# Altium schematic sheet MSP430F5528ZQFE.SchDoc
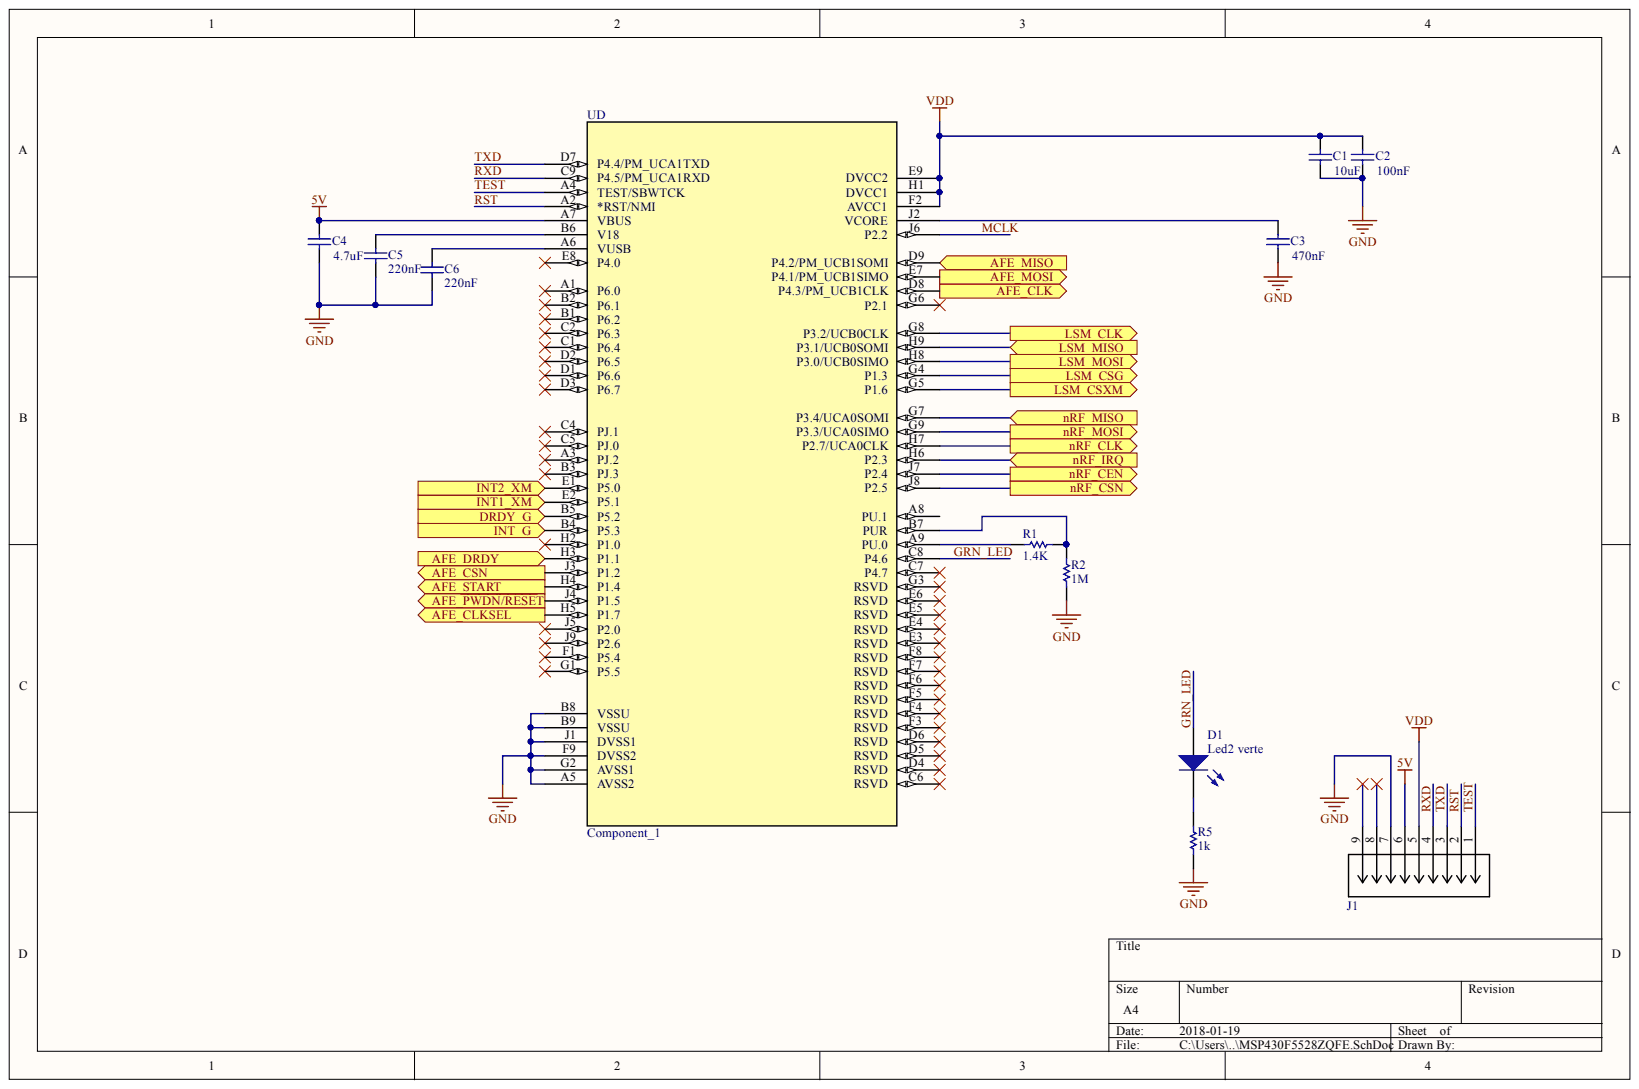
[diagram p0: MSP430F5528ZQFE.SchDoc]

## Parts
C1  value 10uF  [0402]  (hidden comment: Cap Semi)
C2  value 100nF  [0402]  (hidden comment: Cap Semi)
C3  value 470nF  [0402]  (hidden comment: Cap Semi)
C4  value 4.7uF  [0402]  (hidden comment: Cap Semi)
C5  value 220nF  [0402]  (hidden comment: Cap Semi)
C6  value 220nF  [0402]  (hidden comment: Cap Semi)
D1  Led2 verte  [0805 (2012 metric)]
J1  FH12-7S-1SH(55)  [CON7_1X7_DRBF_HS]  (value not drawn)
R1  value 1.4K  [0402]
R2  value 1M  [0402]
R5  value 1k  [0402]
UD  Component_1  [MSP430F5528ZQFE]

## Ports
AFE_CLK, AFE_CLKSEL, AFE_CSN, AFE_DRDY, AFE_MISO, AFE_MOSI, AFE_START, AFE_~{PWDN/RESET}, DRDY_G, INT1_XM, INT2_XM, INT_G, LSM_CLK, LSM_CSG, LSM_CSXM, LSM_MISO, LSM_MOSI, NRF_CEN, NRF_CLK, NRF_CSN, NRF_IRQ, NRF_MISO, NRF_MOSI

## Nets
5V: C4.1 J1.6 UD.A7(VBUS)
GND: C1.2 C2.2 C3.2 C4.2 C5.1 C6.1 J1.7 R2.2 R5.2 UD.A5(AVSS2) UD.B8(VSSU) UD.B9(VSSU) UD.F9(DVSS2) UD.G2(AVSS1) UD.J1(DVSS1)
VDD: C1.1 C2.1 J1.5 UD.E9(DVCC2) UD.F2(AVCC1) UD.H1(DVCC1)
AFE_CLK: UD.D8(P4.3/PM_UCB1CLK) ; port AFE_CLK
AFE_CLKSEL: UD.H5(P1.7) ; port AFE_CLKSEL
AFE_CSN: UD.J3(P1.2) ; port AFE_CSN
AFE_DRDY: UD.H3(P1.1) ; port AFE_DRDY
AFE_MISO: UD.D9(P4.2/PM_UCB1SOMI) ; port AFE_MISO
AFE_MOSI: UD.E7(P4.1/PM_UCB1SIMO) ; port AFE_MOSI
AFE_~{PWDN/RESET}: UD.J4(P1.5) ; port AFE_~{PWDN/RESET}
AFE_START: UD.H4(P1.4) ; port AFE_START
DRDY_G: UD.B5(P5.2) ; port DRDY_G
GRN_LED: D1.1 UD.C8(P4.6)
INT1_XM: UD.E2(P5.1) ; port INT1_XM
INT2_XM: UD.E1(P5.0) ; port INT2_XM
INT_G: UD.B4(P5.3) ; port INT_G
LSM_CLK: UD.G8(P3.2/UCB0CLK) ; port LSM_CLK
LSM_CSG: UD.G4(P1.3) ; port LSM_CSG
LSM_CSXM: UD.G5(P1.6) ; port LSM_CSXM
LSM_MISO: UD.H9(P3.1/UCB0SOMI) ; port LSM_MISO
LSM_MOSI: UD.H8(P3.0/UCB0SIMO) ; port LSM_MOSI
MCLK: UD.J6(P2.2)
RST: J1.2 UD.A2(*RST/NMI)
RXD: J1.4 UD.C9(P4.5/PM_UCA1RXD)
TEST: J1.1 UD.A4(TEST/SBWTCK)
TXD: J1.3 UD.D7(P4.4/PM_UCA1TXD)
NetC3_1: C3.1 UD.J2(VCORE)
NetC5_2: C5.2 UD.B6(V18)
NetC6_2: C6.2 UD.A6(VUSB)
NetD1_2: D1.2 R5.1
NetR1_1: R1.1 R2.1 UD.B7(PUR)
NetR1_2: R1.2 UD.A9(PU.0)
NetUD_G7: UD.G7(P3.4/UCA0SOMI) ; port NRF_MISO
NetUD_G9: UD.G9(P3.3/UCA0SIMO) ; port NRF_MOSI
NetUD_H6: UD.H6(P2.3) ; port NRF_IRQ
NetUD_H7: UD.H7(P2.7/UCA0CLK) ; port NRF_CLK
NetUD_J7: UD.J7(P2.4) ; port NRF_CEN
NetUD_J8: UD.J8(P2.5) ; port NRF_CSN

Not connected: J1 8 9; UD A1(P6.0) A3(PJ.2) A8(PU.1) B1(P6.2) B2(P6.1) B3(PJ.3) C1(P6.4) C2(P6.3) C4(PJ.1) C5(PJ.0) C6(RSVD) C7(P4.7) D1(P6.6) D2(P6.5) D3(P6.7) D4(RSVD) D5(RSVD) D6(RSVD) E3(RSVD) E4(RSVD) E5(RSVD) E6(RSVD) E8(P4.0) F1(P5.4) F3(RSVD) F4(RSVD) F5(RSVD) F6(RSVD) F7(RSVD) F8(RSVD) G1(P5.5) G3(RSVD) G6(P2.1) H2(P1.0) J5(P2.0) J9(P2.6)

## Library data of the parts
C1-C6 = Cap Semi: Capacitor (Semiconductor SIM Model); Component Kind: Standard; LatestRevisionDate: 29-May-2009; LatestRevisionNote: IPC-7351 Footprint Added.; Library Name: Miscellaneous Devices.IntLib; PackageDescription: Chip Capacitor; PackageReference: C1206; Published: 8-Jun-2000; Signal Integrity: Cap Semi; Simulation: CAP; Supplier 1: Digi-Key; Publisher: Altium Limited
  C1 C2: Supplier Part Number 1: 490-10692-2-ND
  C3: Supplier Part Number 1: 1276-1734-2-ND
  C4: Supplier Part Number 1: 490-5915-2-ND
  C5 C6: Supplier Part Number 1: 490-3884-2-ND
D1 = LED3: LED 2X1.2MM 570NM GN WTR CLR SMD; Component Kind: Standard; Library Name: Miscellaneous Devices.IntLib; Signal Integrity: LED; Simulation: LED3; Supplier 1: Digi-Key; Supplier Part Number 1: 754-1651-1-ND
J1 = FH12-7S-1SH(55): Imported; Cable_End_Type: Straight; Connector_Type: Bottom Contacts; Contact_Finish: Gold; Current_Rating: 0.500A; FFC,_FCB_Thickness: 0.30mm; Height_Above_Board: 0.079" (2.00mm); Locking_Feature: Flip Lock; Mounting_Type: Surface Mount, Right Angle; Number_of_Positions: 7; Operating_Temperature: -40Â°C ~ 85Â°C; Pitch: 0.039" (1.00mm); Vendor: Hirose; Voltage_Rating: 50V; Category: Connectors; Component Kind: Standard; Contact_Finish_Thickness: -; DataSheet: http://www.hirose.co.jp/cataloge_hp/e58605370.pdf; DigiKeyPartNumber: HFB107TR-ND; Features: Zero Insertion Force (ZIF); Housing_Material: Polyamide (PA), Nylon; Library Name: HFB107CT-ND.SchLib; Manufacturer_Part_Number: FH12-7S-1SH(55); Packaging: Tape &amp; Reel (TR); Quantity_Available: 10000; RefDes: RefDes; Series: FH12; Termination: Solder; Type: Type; Value: Value; Copyright: Copyright (C) 2015 Accelerated Designs. All rights reserved
R1 R2 R5 = Res Semi: Semiconductor Resistor; Component Kind: Standard; LatestRevisionDate: 17-Jul-2002; LatestRevisionNote: Re-released for DXP Platform.; Library Name: Miscellaneous Devices.IntLib; PackageDescription: Axial Device, Thru-Hole; 2 Leads; 0.5 in Pin Spacing; PackageReference: AXIAL-0.5; Published: 8-Jun-2000; Signal Integrity: Res Semi; Simulation: RES; Supplier 1: Digi-Key; Publisher: Altium Limited
  R1: Supplier Part Number 1: RHM1.4KBHTR-ND
  R2: Supplier Part Number 1: RHM1.00MCDTR-ND
  R5: Supplier Part Number 1: RHM100CDTR-ND
UD = Component_1: Component Kind: Standard; Library Name: MSP430F5528ZQFE.SchLib
